# Altium schematic sheet USB to UART.SchDoc("USB to UART")
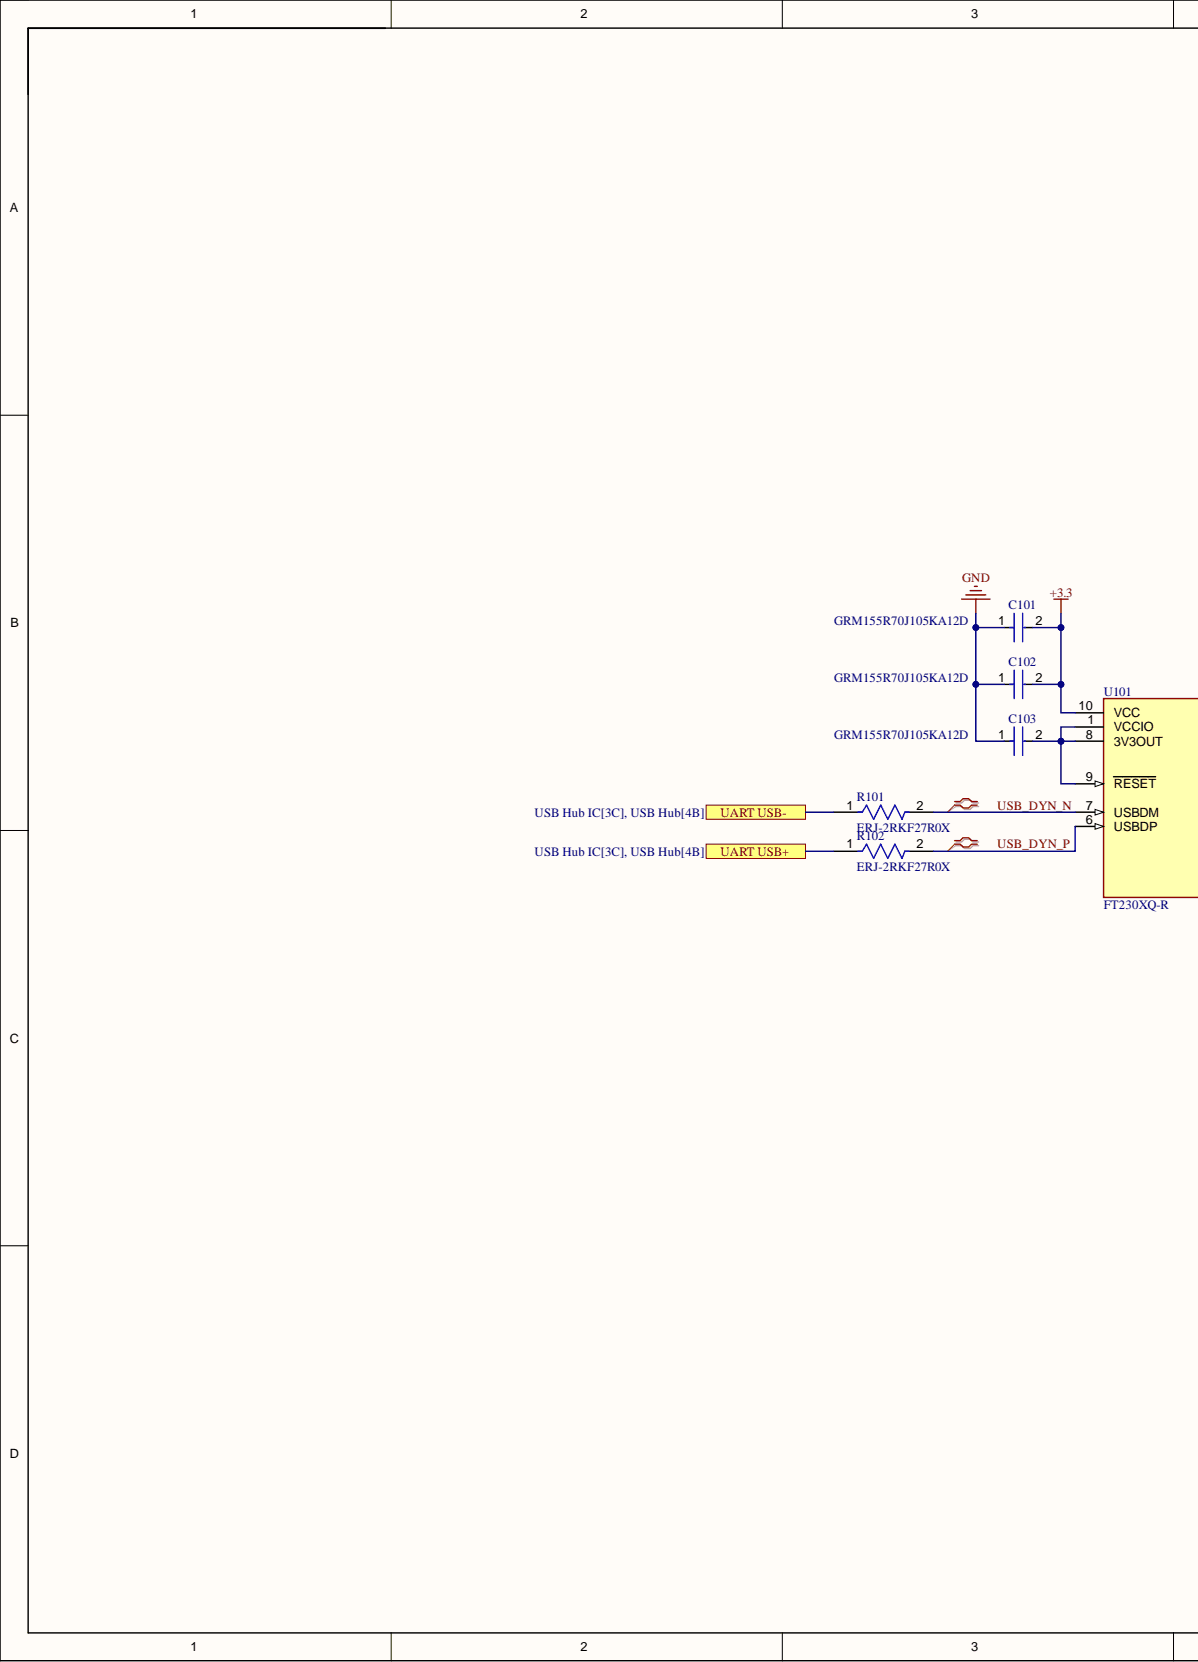
[diagram p0: USB to UART.SchDoc, left]
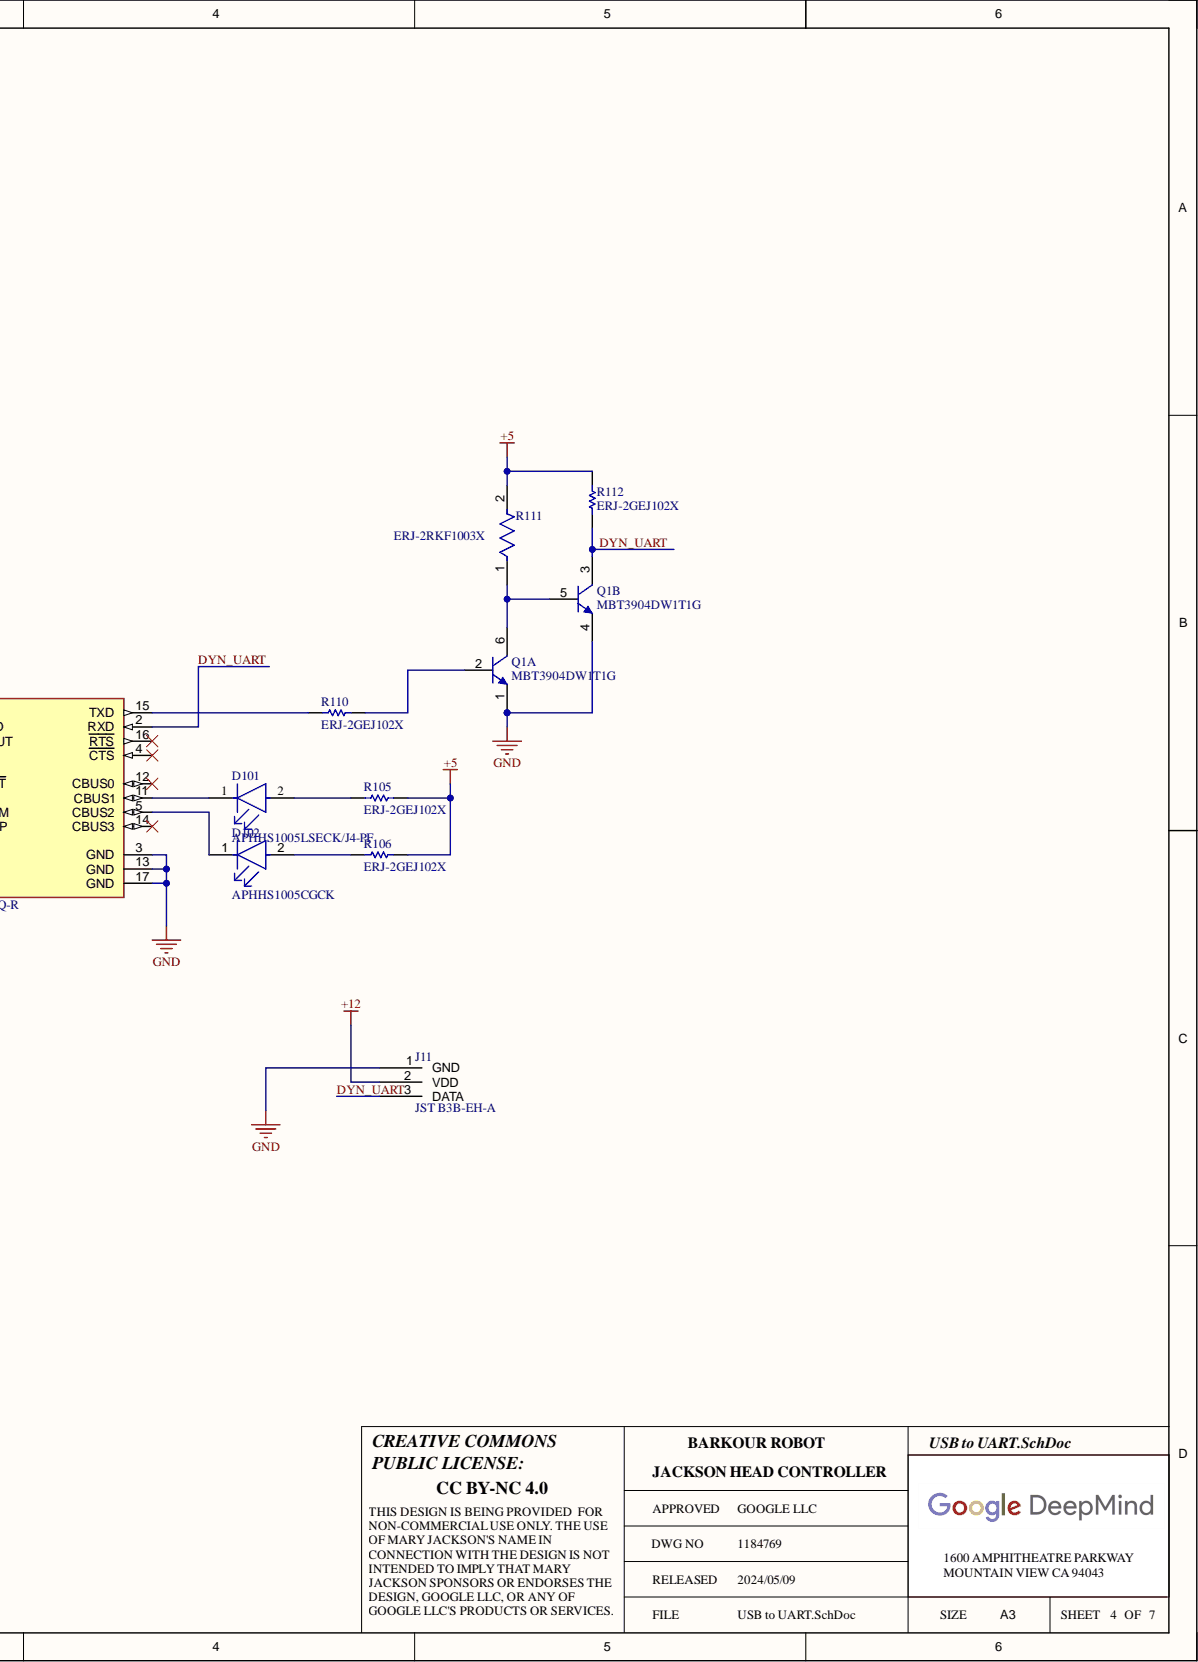
[diagram p1: USB to UART.SchDoc, right]

## Parts
C101  GRM155R70J105KA12D  [FP-GRM155-0_05-MFG]
C102  GRM155R70J105KA12D  [FP-GRM155-0_05-MFG]
C103  GRM155R70J105KA12D  [FP-GRM155-0_05-MFG]
D101  APHHS1005LSECK/J4-PF  [FP-APHHS1005LSECK-J4-PF-MFG]
D102  APHHS1005CGCK  [FP-APHHS1005CGCK-MFG]
J11  JST B3B-EH-A  [JST B3B-EH-A]
Q1  MBT3904DW1T1G  [ONSC-SOT-363-6-419B-02_W_V]  (parts A,B)
R101  ERJ-2RKF27R0X  [FP-ERJ2R-IPC_B]
R102  ERJ-2RKF27R0X  [FP-ERJ2R-IPC_B]
R105  ERJ-2GEJ102X  [RESC1005X40X25NL05T05]
R106  ERJ-2GEJ102X  [RESC1005X40X25NL05T05]
R110  ERJ-2GEJ102X  [RESC1005X40X25NL05T05]
R111  ERJ-2RKF1003X  [FP-ERJ2RK-IPC_C]
R112  ERJ-2GEJ102X  [RESC1005X40X25NL05T05]
U101  FT230XQ-R  [FP-QFN-16-MFG]

## Ports
UART USB+, UART USB-

## Nets
+3.3: C101.2 C102.2 U101.10(VCC)
+5: R105.2 R106.2 R111.2 R112.2
+12: J11.2
GND: C101.1 C102.1 C103.1 J11.1 Q1.1 Q1.4 U101.3(GND) U101.13(GND) U101.17(GND)
DYN_UART: J11.3 Q1.3 R112.1 U101.2(RXD)
USB_DYN_N: R101.2 U101.7(USBDM)
USB_DYN_P: R102.2 U101.6(USBDP)
NetC103_2: C103.2 U101.1(VCCIO) U101.8(3V3OUT) U101.9(RESET)
NetD101_1: D101.1 U101.11(CBUS1)
NetD101_2: D101.2 R105.1
NetD102_1: D102.1 U101.5(CBUS2)
NetD102_2: D102.2 R106.1
NetQ1_2: Q1.2 R110.2
NetQ1_5: Q1.5 Q1.6 R111.1
NetR101_1: R101.1
NetR102_1: R102.1
NetR110_1: R110.1 U101.15(TXD)

Not connected: U101 4(CTS) 12(CBUS0) 14(CBUS3) 16(RTS)

## Library data of the parts
C101-C103 = CMP-2008-04587-2: Chip Multilayer Ceramic Capacitors for General Purpose, 0402, 1.0uF, X7R, 15%, 10%, 6.3V; Capacitance: 1uF; Case Code (Imperial): 0402; Case Code (Metric): 1005; Case/Package: 0402; Component Kind: Standard; Depth: 0.5mm; Dielectric: X7R; Height: 0.5mm; Lead Free: Lead Free; Length: 1mm; Library Name: Altium Content Vault; Material: Ceramic; Max Operating Temperature: 125°C; Min Operating Temperature: -55°C; Mount: Surface Mount; Package Quantity: 10000; Packaging: Tape and Reel; Termination: SMD/SMT; Thickness: 0.022inch; Tolerance: 10%; Voltage Rating: 6.3V; Voltage Rating (DC): 6.3V; Weight: 0.000212oz; Width: 0.5mm
D101 = CMP-00682-82290958-1: APHHS1005LSECK/J4-PF LED Uni-Color SB. Orange 611nm 2-Pin SMD T/R; Forward Current: 2mA; Forward Voltage: 1.8V; Luminous Intensity: 40mcd; Max Junction Temperature (Tj): 115°C; Power Dissipation: 84mW; Reverse Voltage: 5V; Viewing Angle: 120°; Wavelength: 605nm; Case/Package: 0402; Color: Orange; Component Kind: Standard; Height: 600µm; Library Name: Altium Content Vault; Max Operating Temperature: 85°C; Min Operating Temperature: -40°C; NexarPartId: 82290958; RoHS: Non-Compliant; Schedule B: 8541402000, 8541402000
D102 = CMP-44529-000044-1: LED GREEN CLEAR CHIP SMD; Dominant Wavelength: 570nm; Forward Current: 20mA; Forward Voltage: 2.1V; Luminous Intensity: 50mcd; Number of LEDs: 1; Viewing Angle: 120°; Case Code (Imperial): 0402; Case Code (Metric): 1005; Case/Package: 0402; Color: Green; Component Kind: Standard; Height: 0.6mm; Illumination Color: Green; Lead Free: Lead Free; Length: 1mm; Lens Color: Clear; Lens Style: Clear; Library Name: Altium Content Vault; Max Operating Temperature: 85°C; Min Operating Temperature: -40°C; Mount: Surface Mount; Number of Pins: 2; Package Quantity: 2000; Packaging: Tape and Reel; Test Current: 20mA; Wavelength: 570nm; Width: 0.5mm
Q1 = CMP-1048-00877-1: Dual General Purpose Transistors, 6-Pin SOT-363, Pb-Free, Tape and Reel; Manufacturer: On Semiconductor; fT Min (MHz): 300; IC Continuous (A): 0.2; Polarity: Dual NPN; Component Kind: Standard; ComponentLink1Description: Manufacturer URL; ComponentLink1URL: http://www.onsemi.com/; ComponentLink2Description: Datasheet; ComponentLink2URL: http://www.onsemi.com/pub_link/Collateral/MBT3904DW1T1-D.PDF; ComponentLink3Description: Package Specification; ComponentLink3URL: http://www.onsemi.com/pub_link/Collateral/NTJD4001N-D.PDF; DatasheetVersion: Rev. 9; Library Name: Altium Content Vault; Mounting Technology: Surface Mount; PackageDescription: 6-Pin Small Outline Transistor, Body 2 x 1.25 mm, Pitch 0.65 mm; PackageReference: SOT-363-6-419B-02_W; PackageVersion: Rev. W, 01/2006; Packing: Tape and Reel; PartNumber: MBT3904DW1T1G; RoHS: TRUE
R101 R102 = CMP-2002-00830-2: RES SMD 27 OHM 1% 1/10W 0402; Max Power Dissipation: 100mW; Resistance: 27R; Temperature Coefficient: 100ppm/°C; Voltage Rating: 50V; Case Code (Imperial): 0402; Case Code (Metric): 1005; Case/Package: 0402; Component Kind: Standard; Construction: Rectangular; Contact Plating: Tin; Depth: 0.5mm; Features: Automotive AEC-Q200; Height: 0.35mm; Lead Free: Lead Free; Length: 1mm; Library Name: Altium Content Vault; Max Operating Temperature: 155°C; Military Standard: Not; Min Operating Temperature: -55°C; Mount: Surface Mount; Number of Pins: 2; Number of Terminations: 2; Package Quantity: 1; Packaging: Tape and Reel; Power Rating: 100mW; Radiation Hardening: No; REACH SVHC: No SVHC; Resistance Tolerance: 1%; Resistor Type: Thick Film; RoHS Compliant: Yes; Series: ERJ; Technology: THICK FILM; Termination: SMD/SMT; Tolerance: 1%; Voltage Rating (DC): 50V; Weight: 2.8E-05oz; Width: 0.5mm
R105 R106 R110 R112 = CMP-2002-00432-1: Manufacturer: Panasonic; Component Kind: Standard; Library Name: Altium Content Vault; Part Number: ERJ-2GEJ102X
R111 = CMP-2002-00608-4: RES SMD 100K OHM 1% 1/10W 0402; Max Power Dissipation: 100mW; Resistance: 100kR; Temperature Coefficient: 100ppm/°C; Voltage Rating: 50V; Case Code (Imperial): 0402; Case Code (Metric): 1005; Case/Package: 0402; Component Kind: Standard; Composition: Thick Film; Contact Plating: Tin; Depth: 0.5mm; Features: Automotive AEC-Q200; Height: 0.35mm; Lead Free: Lead Free; Length: 1mm; Library Name: Altium Content Vault; Max Operating Temperature: 155°C; Military Standard: Not; Min Operating Temperature: -55°C; Mount: Surface Mount; Number of Pins: 2; Number of Terminations: 2; Package Quantity: 1; Packaging: Tape and Reel; Power Rating: 100mW; Radiation Hardening: No; REACH SVHC: No SVHC; Termination: SMD/SMT; Tolerance: 1%; Voltage Rating (DC): 50V; Width: 0.5mm
U101 = CMP-0248-00010-3: IC USB SERIAL BASIC UART 16QFN; Data Rate: 12Mbps; Max Supply Voltage: 5.5V; Min Supply Voltage: 2.97V; Number of Channels: 1; Operating Supply Current: 8mA; Operating Supply Voltage: 5V; Case/Package: QFN; Component Kind: Standard; Height: 0.75mm; Interface: USB; Lead Free: Lead Free; Length: 4mm; Library Name: Altium Content Vault; Max Operating Temperature: 85°C; Min Operating Temperature: -40°C; Mount: Surface Mount; Number of Pins: 16; Package Quantity: 5000; Packaging: Tape and Reel; Weight: 0.002014oz; Width: 4mm
J11 = JST B3B-EH-A: Component Kind: Standard; Library Name: Schlib1.SchLib
